# Altium schematic sheet Battery Spot Welder.schdoc
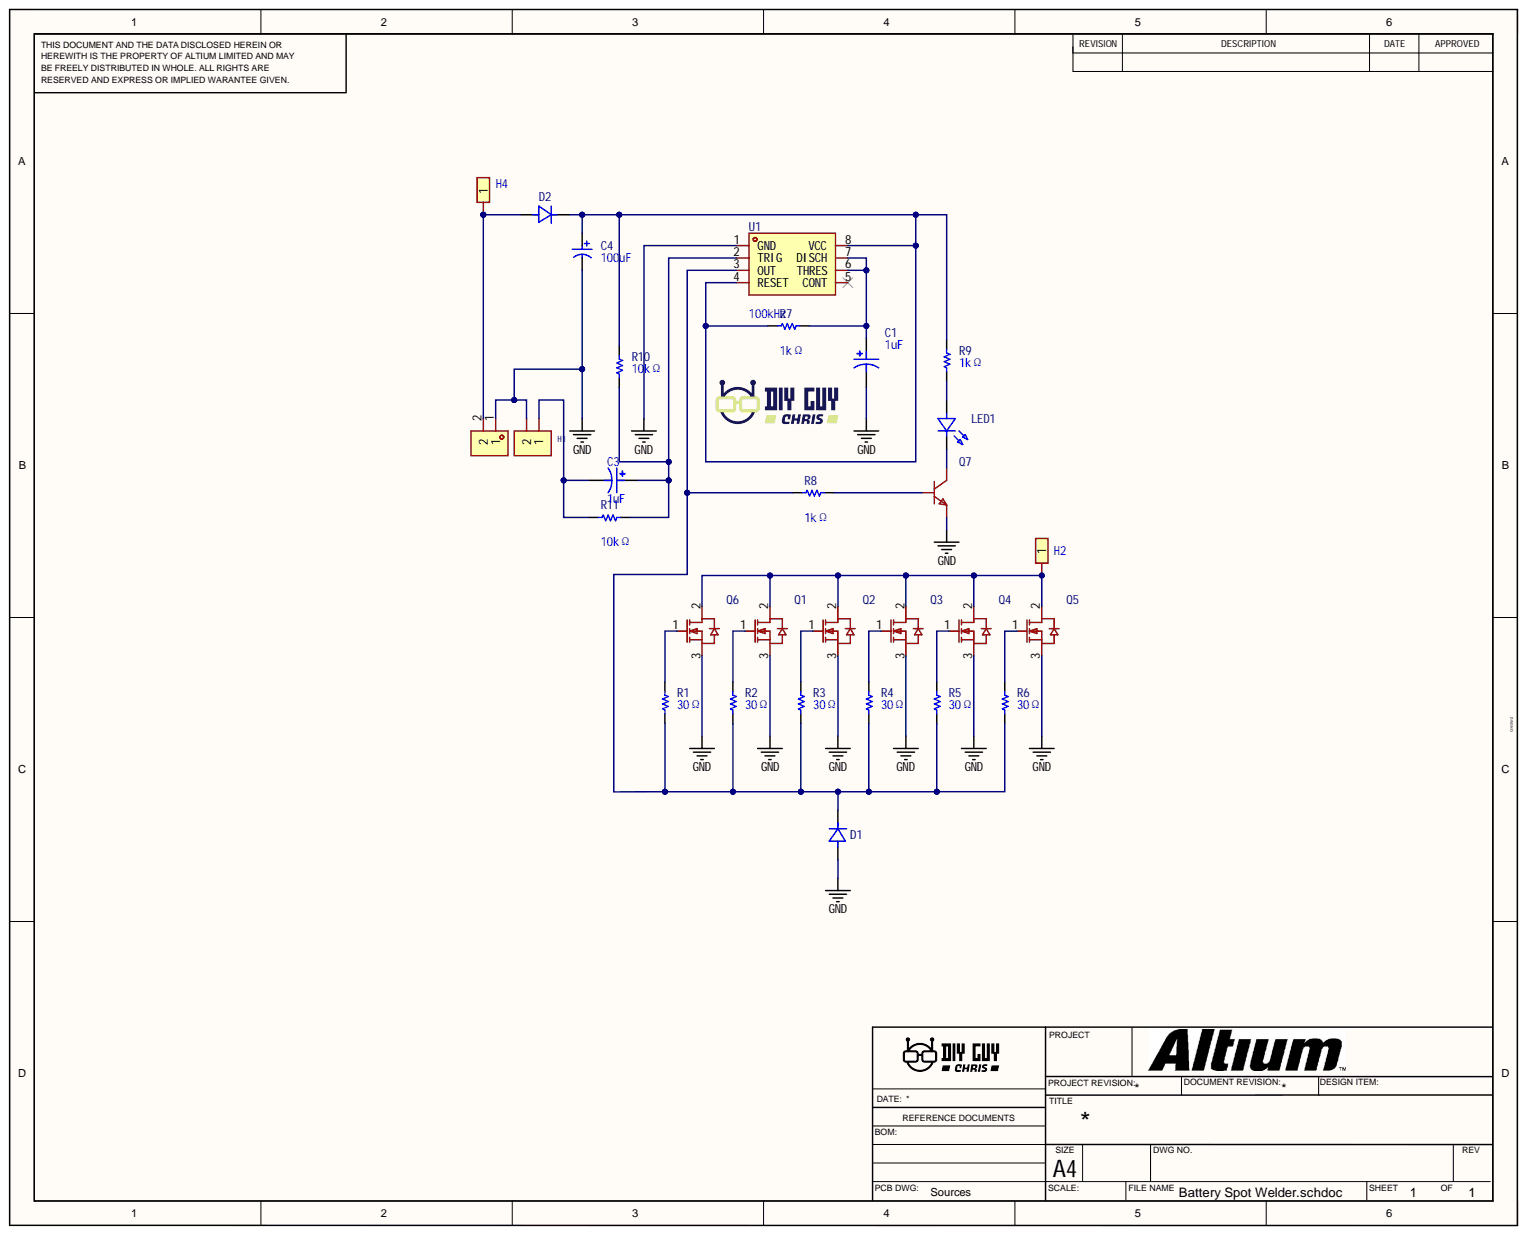
[diagram p0: Battery Spot Welder.schdoc]

## Parts
C1  1uF  [CAP-TH_BD5.0-P2.00-D0.8-FD]
C3  1uF  [CAP-TH_BD5.0-P2.00-D0.8-FD]
C4  100uF  [CAP-TH_BD5.0-P2.00-D0.8-FD]
D1  1N4007RLG  [DO-41_BD2.4-L4.7-P8.70-D0.9-RD]  (value not drawn)
D2  1N4007RLG  [DO-41_BD2.4-L4.7-P8.70-D0.9-RD]  (value not drawn)
H1  (no value)  [HDR-TH_2P-P2.54-V-F]
H2  (no value)  [HDR-TH_1P-V-M_XKB_X4611WV-01I-C28D40]
H4  (no value)  [HDR-TH_1P-V-M_XKB_X4611WV-01I-C28D40]
LED1  DY-324SVRC-H23-P-A4(FH)  [LED-TH_BD5.8-P2.54-RD]  (value not drawn)
Q1  IRLB4132PBF  [TO-220-3_L10.0-W4.5-P2.54-T]  (value not drawn)
Q2  IRLB4132PBF  [TO-220-3_L10.0-W4.5-P2.54-T]  (value not drawn)
Q3  IRLB4132PBF  [TO-220-3_L10.0-W4.5-P2.54-T]  (value not drawn)
Q4  IRLB4132PBF  [TO-220-3_L10.0-W4.5-P2.54-T]  (value not drawn)
Q5  IRLB4132PBF  [TO-220-3_L10.0-W4.5-P2.54-T]  (value not drawn)
Q6  IRLB4132PBF  [TO-220-3_L10.0-W4.5-P2.54-T]  (value not drawn)
Q7  BC547BTA  [TO-92-3_L4.8-W3.7-P2.54-L]  (value not drawn)
R1  30Ω  [RES-TH_BD3.3-L9.0-P13.00-D0.6]
R2  30Ω  [RES-TH_BD3.3-L9.0-P13.00-D0.6]
R3  30Ω  [RES-TH_BD3.3-L9.0-P13.00-D0.6]
R4  30Ω  [RES-TH_BD3.3-L9.0-P13.00-D0.6]
R5  30Ω  [RES-TH_BD3.3-L9.0-P13.00-D0.6]
R6  30Ω  [RES-TH_BD3.3-L9.0-P13.00-D0.6]
R7  1kΩ  [RES-TH_BD3.3-L9.2-P13.20-D0.6]
R8  1kΩ  [RES-TH_BD3.3-L9.2-P13.20-D0.6]
R9  1kΩ  [RES-TH_BD3.3-L9.2-P13.20-D0.6]
R10  10kΩ  [RES-TH_BD4.0-L11.5-P15.50-D0.7]
R11  10kΩ  [RES-TH_BD4.0-L11.5-P15.50-D0.7]
U1  100kHz  [DIP-8_L9.8-W6.6-P2.54-LS7.6-BL]
U2  DB128L-5.08-2P-OG-S  [CONN-TH_2P-P5.08_DB128L-5.08-2P-OG-S]  (value not drawn)

## Nets
GND: C1.2 C4.2 D1.2 H1.2 Q1.3 Q2.3 Q3.3 Q4.3 Q5.3 Q6.3 Q7.3 U1.1(GND) U2.1
NetC1_1: C1.1 R7.2 U1.6(THRES) U1.7(DISCH)
NetC3_1: C3.1 R10.1 R11.2 U1.2(TRIG)
NetC3_2: C3.2 H1.1 R11.1
NetC4_1: C4.1 D2.1 R7.1 R9.2 R10.2 U1.4(RESET) U1.8(VCC)
NetD1_1: D1.1 R1.1 R2.1 R3.1 R4.1 R5.1 R6.1 R8.1 U1.3(OUT)
NetD2_2: D2.2 H4.1 U2.2
NetH2_1: H2.1 Q1.2 Q2.2 Q3.2 Q4.2 Q5.2 Q6.2
NetLED1_1: LED1.1 Q7.1
NetLED1_2: LED1.2 R9.1
NetQ1_1: Q1.1 R2.2
NetQ2_1: Q2.1 R3.2
NetQ3_1: Q3.1 R4.2
NetQ4_1: Q4.1 R5.2
NetQ5_1: Q5.1 R6.2
NetQ6_1: Q6.1 R1.2
NetQ7_2: Q7.2 R8.2

Not connected: U1 5(CONT)

## Library data of the parts
C1 C3 = ERS1HM010D11OT: Manufacturer: AISHI(艾华集团); Add into BOM: yes; Lifetime @ Temp: 5000hrs@105℃; Tolerance: ±20%; Component Kind: Standard; Convert to PCB: yes; Datasheet: https://atta.szlcsc.com/upload/public/pdf/source/20191015/C438940_4B8E60A742BB0AE40DDEF87A5C89089D.pdf; Device: ERS1HM010D11OT; JLCPCB Part Class: Extended Part; LCSC Part Name: 1uF ±20% 50V; Manufacturer Part: ERS1HM010D11OT; PCB3D: CAP-TH_D5.0×H7.0×P2.0; Supplier: LCSC; Supplier Footprint: 插件,D5xL11mm; Supplier Part: C383040; Symbol: ERS1HM010D11OT; Value: 1uF
C4 = KS107M016D07RR0VH2FP0: Manufacturer: CX(承兴); Add into BOM: yes; Lifetime @ Temp: 1000hrs@105℃; Symbol: 100uF 16V 5*7; Tolerance: ±20%; Component Kind: Standard; Convert to PCB: yes; Datasheet: https://atta.szlcsc.com/upload/public/pdf/source/20230817/0DB19D47181DB7F2222DAA6444591EF5.pdf; Device: KS107M016D07RR0VH2FP0; Equivalent Series Resistance(ESR): -; JLCPCB Part Class: Extended Part; LCSC Part Name: 100uF ±20% 16V; Manufacturer Part: KS107M016D07RR0VH2FP0; Operating Temperature: -40℃~+105℃; PCB3D: CAP-TH_D5.0×H7.0×P2.0; Ripple Current: -; Supplier: LCSC; Supplier Footprint: 插件,D5xL7mm; Supplier Part: C43803; Value: 100uF
D1 D2 = 1N4007RLG: Manufacturer: onsemi(安森美); Add into BOM: yes; Forward Voltage (Vf@If): 930mV@1A; Reverse Leakage Current: 50nA@1kV; Component Kind: Standard; Convert to PCB: yes; Datasheet: https://atta.szlcsc.com/upload/public/pdf/source/20180118/C150254_15162576308731279618.pdf; Device: 1N4007RLG; JLCPCB Part Class: Extended Part; LCSC Part Name: 电压:1kV 电流:1A; Manufacturer Part: 1N4007RLG; PCB3D: DO-41_D2.4-L4.7-P8.70; Supplier: LCSC; Supplier Footprint: DO-41; Supplier Part: C53568; Symbol: 1N4007RLG
H1 = HDR-F_2.54_1x2P: Manufacturer: BOOMELE(博穆精密); Manufacturer Part: 2.54-1*2P母; Add into BOM: yes; Symbol: Header-Female-2.54_1x2; Component Kind: Standard; Convert to PCB: yes; Device: HDR-F_2.54_1x2P; JLCPCB Part Class: Extended Part; PCB3D: HDR-TH_2P-P2.54-F-LI-1X2P; Supplier: LCSC; Supplier Footprint: Through; Supplier Part: C49661
H2 H4 = HDR-M_2.54_1x1P: Add into BOM: yes; Symbol: HDR_1x1; Component Kind: Standard; Convert to PCB: yes; Device: HDR-M_2.54_1x1P; PCB3D: HDR-TH_1P-V-M_XKB_X4611WV-01I-C28D40; Supplier Footprint: Through
LED1 = DY-324SVRC-H23-P-A4(FH): Manufacturer: TONYU(东裕); Add into BOM: yes; Luminous Intensity: 7800mcd; Power(Watts): 60mW; Viewing Angle: 35°; Voltage - Forward(Vf): 1.8V~2.4V; Wavelength - Dominant: 620nm~625nm; Component Kind: Standard; Convert to PCB: yes; Datasheet: https://atta.szlcsc.com/upload/public/pdf/source/20230726/8643334EAB143D332CC61AF01F9FE79A.pdf; Device: DY-324SVRC-H23-P-A4(FH); Forward Current: -; JLCPCB Part Class: Extended Part; LCSC Part Name: 5mm圆头有边正红色; Manufacturer Part: DY-324SVRC-H23-P-A4(FH); PCB3D: LED-TH_BD5.8-H8.6-P2.54; Supplier: LCSC; Supplier Footprint: D=5mm; Supplier Part: C7470729; Symbol: DY-324SVRC-H23-P-A4(FH)
Q1-Q6 = IRLB4132PBF: Manufacturer: Infineon(英飞凌); Add into BOM: yes; Drain Source On Resistance (RDS(on)@Vgs,Id): 3.5mΩ@10V,40A; Gate Threshold Voltage (Vgs(th)@Id): 1.35V@100uA; Input Capacitance (Ciss@Vds): 5110pF; Reverse Transfer Capacitance (Crss@Vds): 440pF@15V; Total Gate Charge (Qg@Vgs): 36nC@15V; Type: 1 N-Channel; Component Kind: Standard; Convert to PCB: yes; Datasheet: https://atta.szlcsc.com/upload/public/pdf/source/20180416/C212021_0BD8E44A33353C956391C09F3A14C09C.pdf; Device: IRLB4132PBF; JLCPCB Part Class: Extended Part; LCSC Part Name: 1个N沟道 耐压:30V 电流:150A; Manufacturer Part: IRLB4132PBF; Operating Temperature: -55℃~+175℃; PCB3D: TO-220-3_L10.0-W4.5-P2.54; Supplier: LCSC; Supplier Footprint: TO-220AB; Supplier Part: C212021; Symbol: IRLB4132PBF
Q7 = BC547BTA: Manufacturer: onsemi(安森美); Add into BOM: yes; Collector Cut-Off Current (Icbo): 15nA; Collector-Emitter Saturation Voltage (VCE(sat)@Ic,Ib): 90mV@10mA,0.5mA; DC Current Gain (hFE@Ic,Vce): 110@2mA,5V; Transition Frequency (fT): 300MHz; Component Kind: Standard; Convert to PCB: yes; Datasheet: https://atta.szlcsc.com/upload/public/pdf/source/20181011/C258144_558FF79AFD5DFF45A84CD9911C86EE0E.pdf; Device: BC547BTA; JLCPCB Part Class: Extended Part; LCSC Part Name: NPN 电流:100mA 耐压:45V; Manufacturer Part: BC547BTA; Operating Temperature: -65℃~+150℃; PCB3D: TO-92-3_L4.8-W3.7-H4.8-P2.54; Supplier: LCSC; Supplier Footprint: TO-92-3L; Supplier Part: C258144; Symbol: BC547BTA
R1-R6 = RN1WS30ΩFT/BA1: Manufacturer: Tyohm(幸亚电阻); Add into BOM: yes; Power(Watts): 1W; Temperature Coefficient: ±50ppm/℃; Tolerance: ±1%; Component Kind: Standard; Convert to PCB: yes; Datasheet: https://atta.szlcsc.com/upload/public/pdf/source/20191008/C433681_B72693A7FD256E0D1DC5EFE3F095EED3.pdf; Device: RN1WS30ΩFT/BA1; JLCPCB Part Class: Extended Part; LCSC Part Name: 30Ω ±1% 1W 金属膜电阻; Manufacturer Part: RN1WS30ΩFT/BA1; PCB3D: RES-TH_BD3.3-L9.0-P13.00-D0.6; Supplier: LCSC; Supplier Footprint: 插件,D3.3xL9.2mm; Supplier Part: C385373; Symbol: RN1WS30ΩFT/BA1; Type: Metal Film Resistors; Value: 30Ω
R7-R9 = RN1WS1KΩFT/BA1: Manufacturer: Tyohm(幸亚电阻); Add into BOM: yes; Power(Watts): 1W; Temperature Coefficient: ±50ppm/℃; Tolerance: ±1%; Component Kind: Standard; Convert to PCB: yes; Datasheet: https://atta.szlcsc.com/upload/public/pdf/source/20191008/C433681_B72693A7FD256E0D1DC5EFE3F095EED3.pdf; Device: RN1WS1KΩFT/BA1; JLCPCB Part Class: Extended Part; LCSC Part Name: 1kΩ ±1% 1W 金属膜电阻; Manufacturer Part: RN1WS1KΩFT/BA1; PCB3D: RES-TH_BD3.3-L9.2-P13.20-D0.6; Supplier: LCSC; Supplier Footprint: 插件,D3.3xL9.2mm; Supplier Part: C385291; Symbol: RN1WS1KΩFT/BA1; Type: Metal Film Resistors; Value: 1kΩ
R10 R11 = MOR01SJ0103A10: Manufacturer: UNI-ROYAL(厚声); Add into BOM: yes; Power(Watts): 1W; Temperature Coefficient: ±350ppm/℃; Tolerance: ±5%; Voltage-Supply(Max): 350V; Component Kind: Standard; Convert to PCB: yes; Datasheet: https://atta.szlcsc.com/upload/public/pdf/source/20180614/C90124_11786F2444E9B2C178423E242A0314B4.pdf; Device: MOR01SJ0103A10; JLCPCB Part Class: Extended Part; LCSC Part Name: 10kΩ ±5% 1W 金属氧化膜电阻 金属氧化膜电阻 铜脚，圆柱状直插; Manufacturer Part: MOR01SJ0103A10; PCB3D: RES-TH_BD4.0-L11.5-P15.50; Supplier: LCSC; Supplier Footprint: 插件,D3.5xL9.5mm; Supplier Part: C64666; Symbol: MOR01SJ0103A10; Type: Metal Oxide Resistors; Value: 10kΩ
U1 = NE555P: Manufacturer: TI(德州仪器); Add into BOM: yes; Supply Current: 10mA; Supply Voltage: 4.5V~16V; Component Kind: Standard; Convert to PCB: yes; Datasheet: https://www.ti.com/cn/lit/gpn/ne555; Device: NE555P; JLCPCB Part Class: Extended Part; LCSC Part Name: NE555P; Manufacturer Part: NE555P; Operating Temperature: 0℃~+70℃; PCB3D: DIP-8_L9.8-W6.6-H3.5-LS7.62-P2.54; Supplier: LCSC; Supplier Footprint: DIP-8; Supplier Part: C46749; Symbol: NE555P; Value: 100kHz
U2 = DB128L-5.08-2P-OG-S: Manufacturer: DORABO(地博电气); Add into BOM: yes; Current Rating: 16A; Number of PINs Per Row: 2; Number of Positions or Pins: 1x2P; Pitch: 5.08mm; Screw Specification: M2; Voltage Rating (Max): 300V; Wire Gauge - AWG: 12~22; Wire Gauge - mm2: 2.5; Color: Orange; Component Kind: Standard; Convert to PCB: yes; Datasheet: https://atta.szlcsc.com/upload/public/pdf/source/20230106/B067FE0E641A396A370D47F93C345689.pdf; Device: DB128L-5.08-2P-OG-S; JLCPCB Part Class: Extended Part; LCSC Part Name: 1x2P 5.08mm 直插 排数:1 每排P数:2; Manufacturer Part: DB128L-5.08-2P-OG-S; Mounting Type: Through Hole; Number of Pins: 2P; Number of Rows: 1; Operating Temperature: -40℃~+105℃; PCB3D: CONN-TH_2P-P5.08_DB128L-5.08-2P-OG-S; Supplier: LCSC; Supplier Footprint: 插件,P=5.08mm; Supplier Part: C430603; Symbol: DB128L-5.08-2P-OG-S
